# Altium schematic sheet 05_VALVE + heating -1031.SchDoc
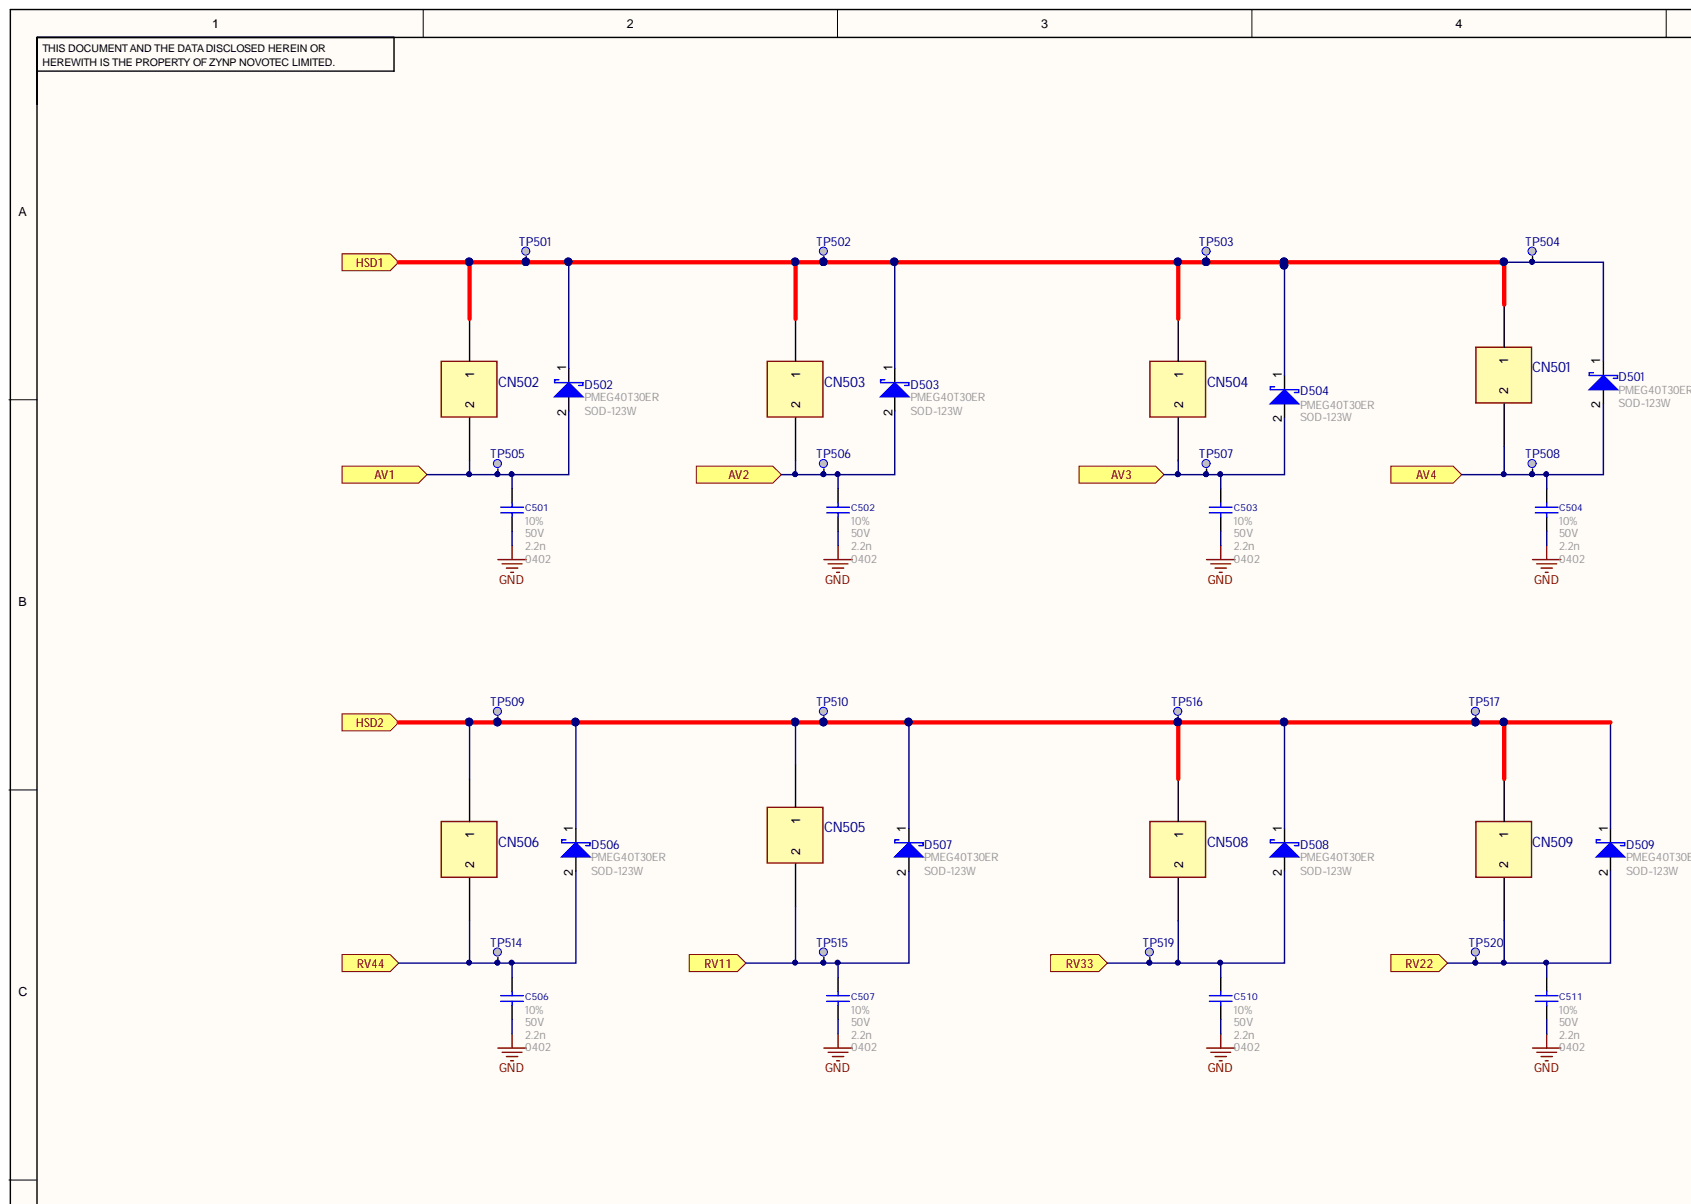
[diagram p0: 05_VALVE + heating -1031.SchDoc, top left]
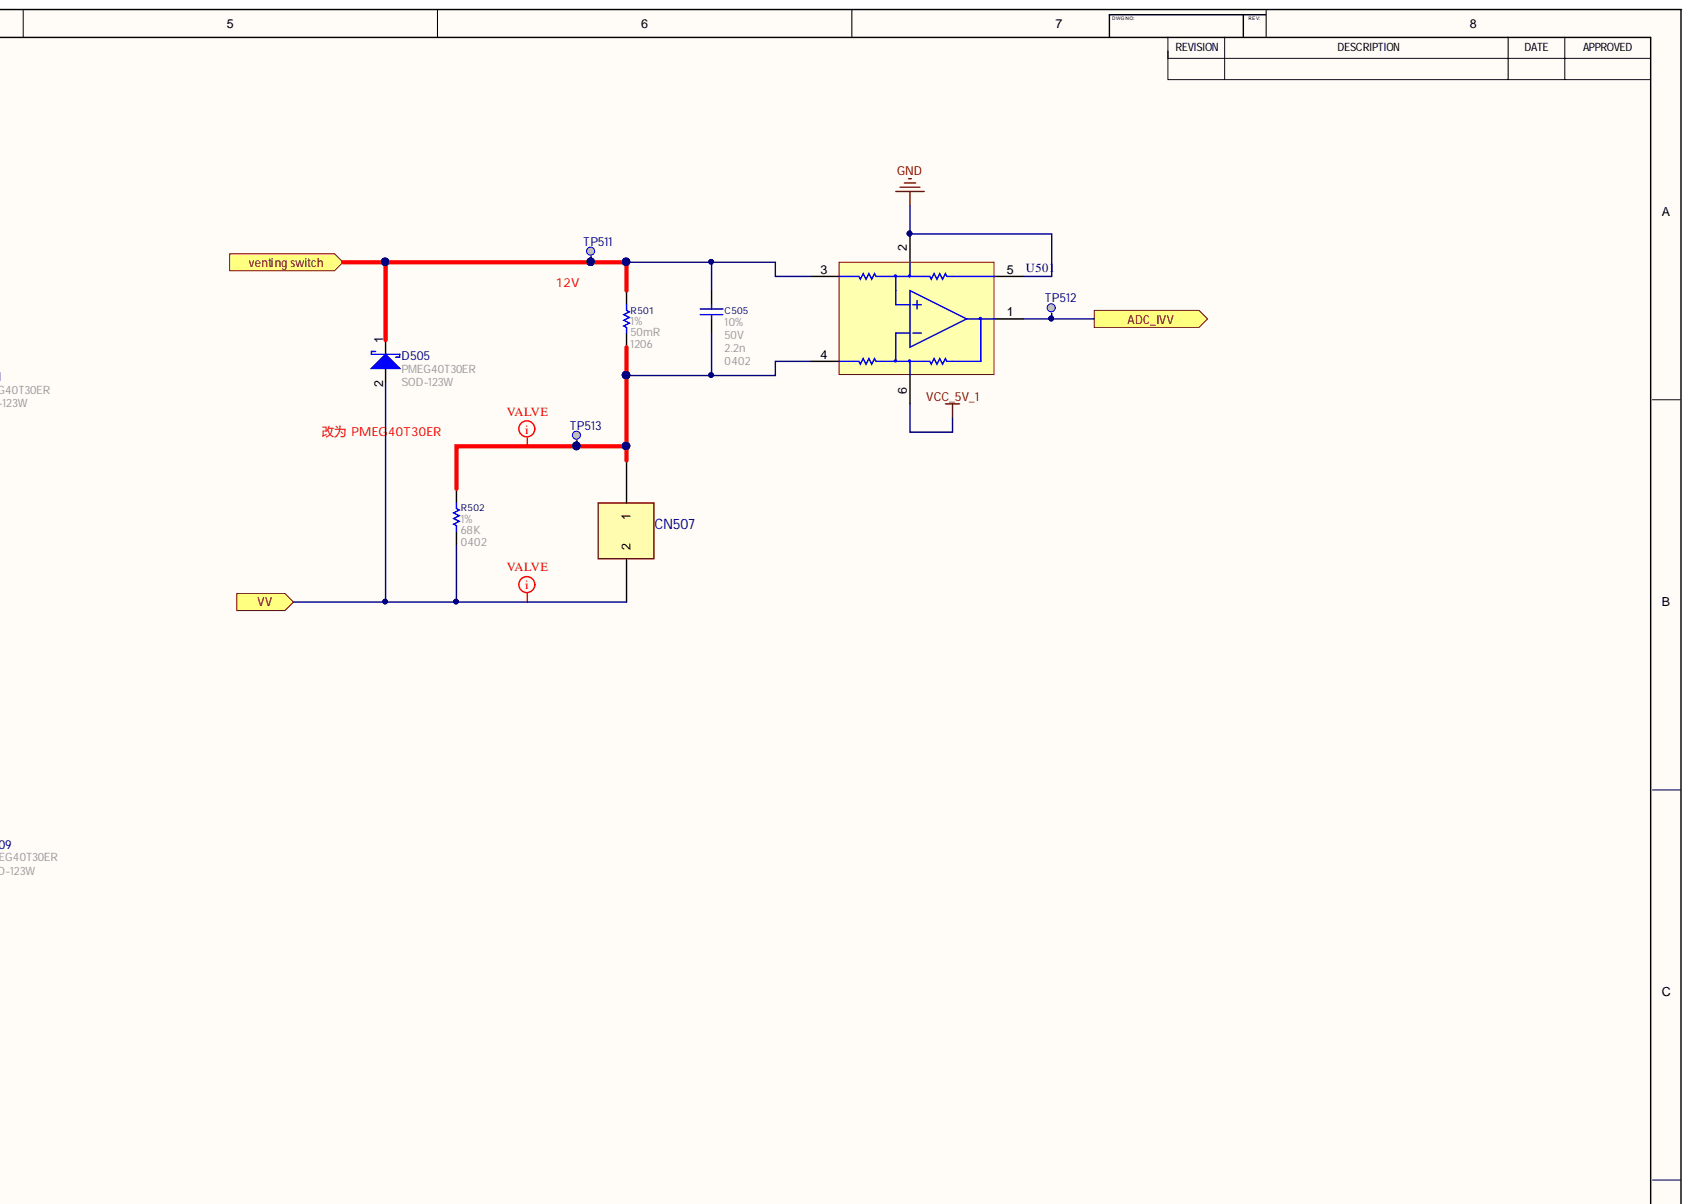
[diagram p1: 05_VALVE + heating -1031.SchDoc, top right]
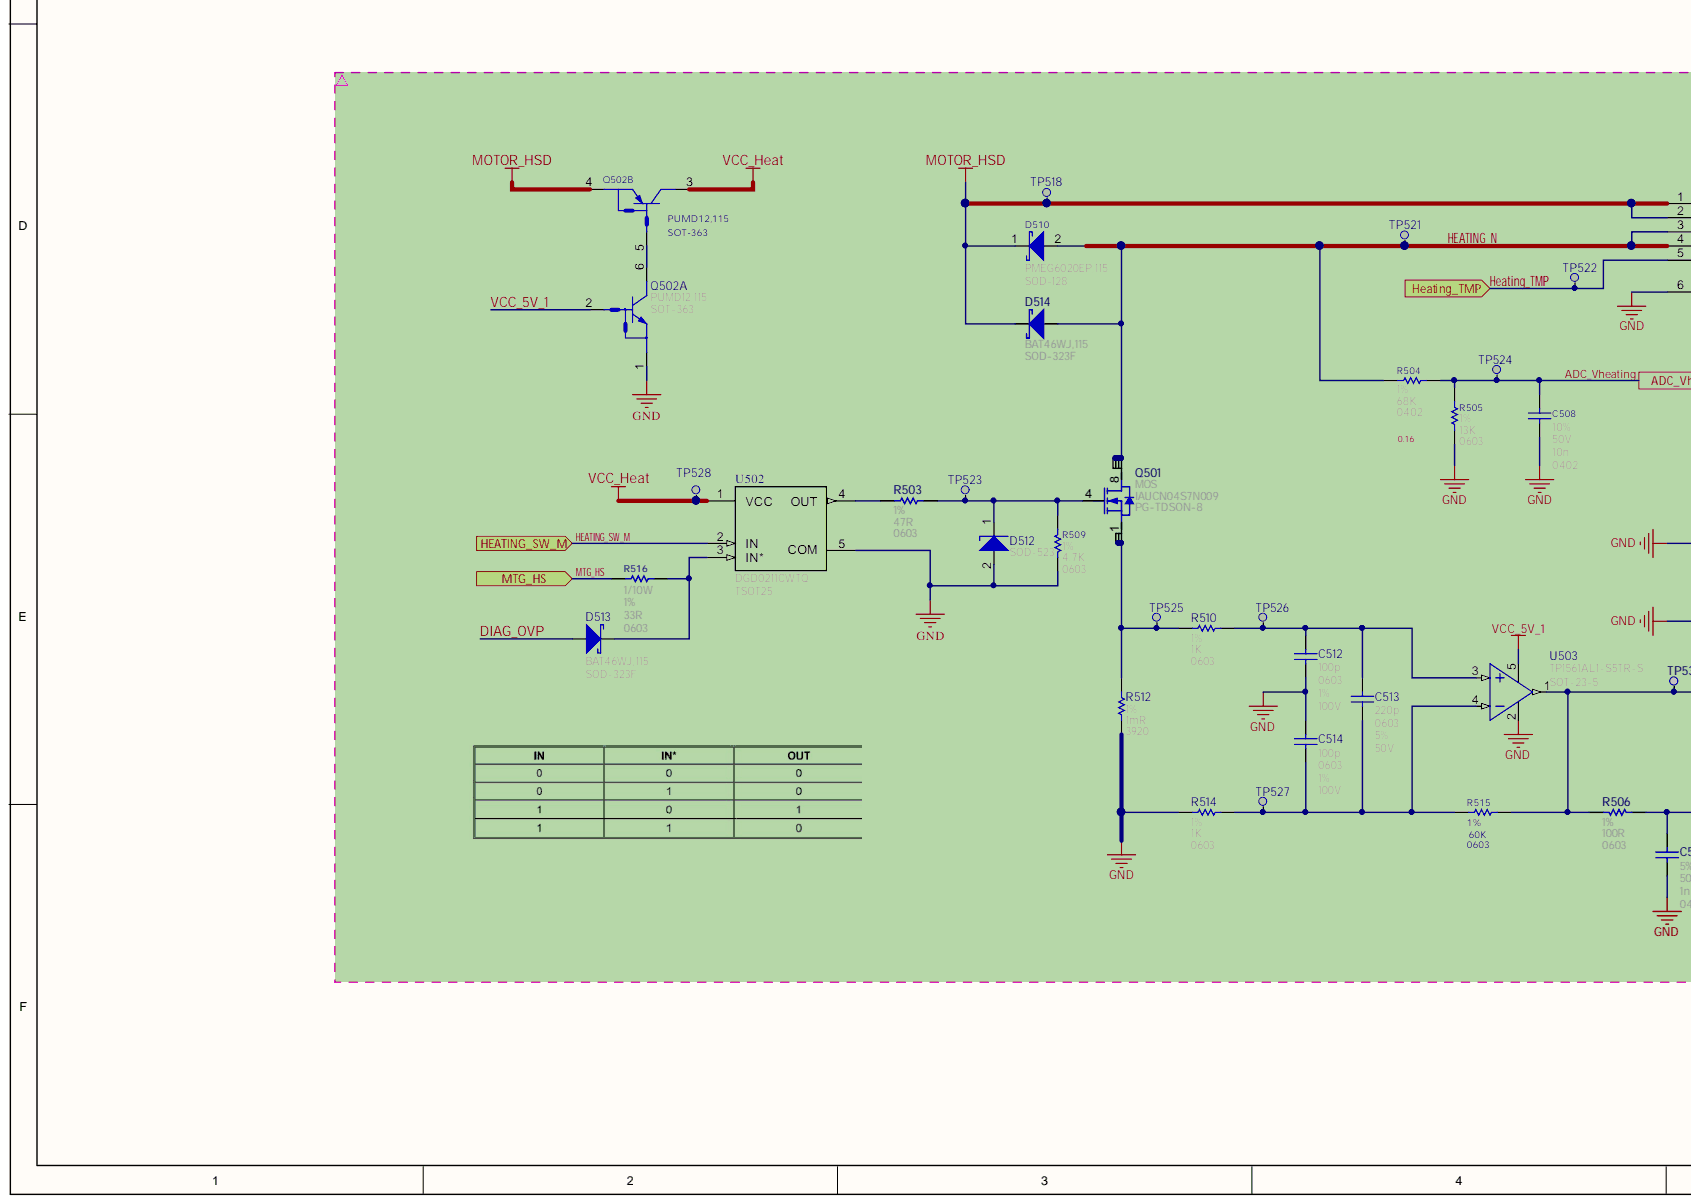
[diagram p2: 05_VALVE + heating -1031.SchDoc, bottom left]
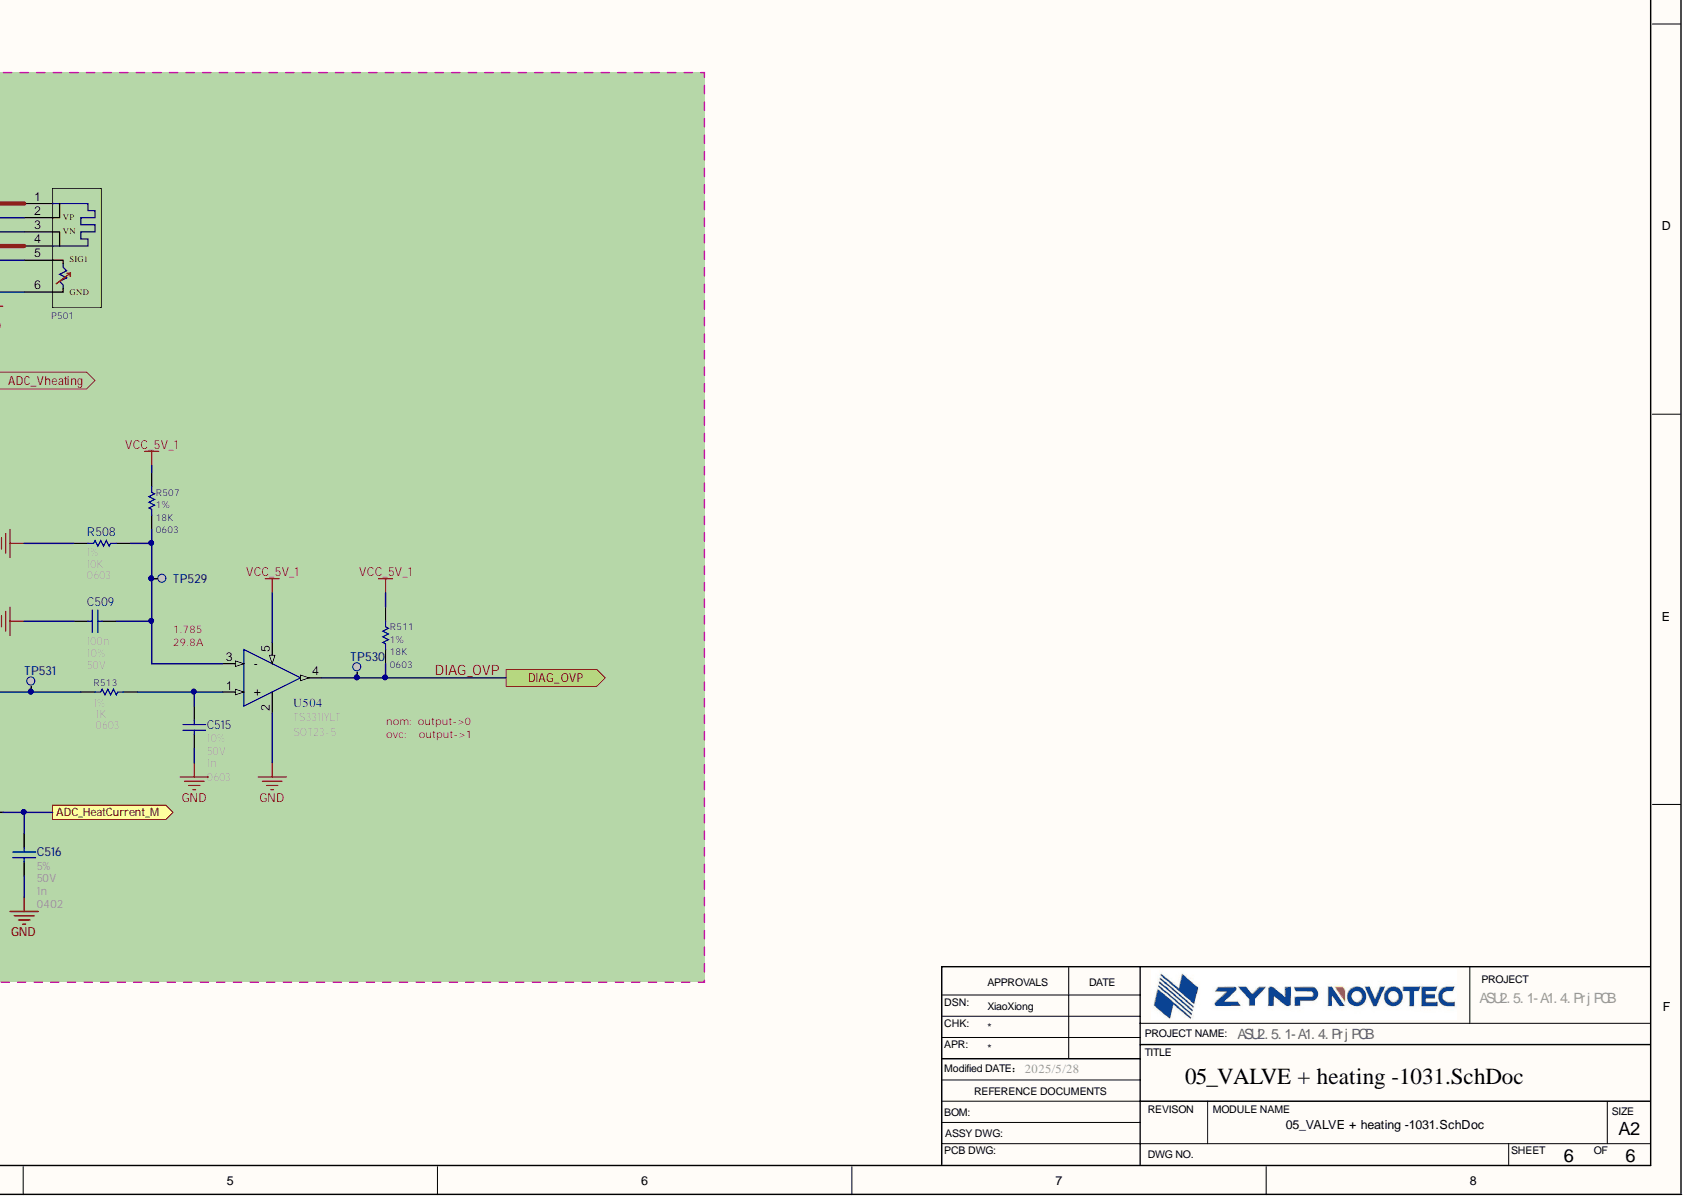
[diagram p3: 05_VALVE + heating -1031.SchDoc, bottom right]

## Parts
C501  value 2.2n  Package: 0402  Tolerance: 10%  Voltage: 50V  [C0402]  (hidden comment: CAP)
C502  value 2.2n  Package: 0402  Tolerance: 10%  Voltage: 50V  [C0402]  (hidden comment: CAP)
C503  value 2.2n  Package: 0402  Tolerance: 10%  Voltage: 50V  [C0402]  (hidden comment: CAP)
C504  value 2.2n  Package: 0402  Tolerance: 10%  Voltage: 50V  [C0402]  (hidden comment: CAP)
C505  value 2.2n  Package: 0402  Tolerance: 10%  Voltage: 50V  [C0402]  (hidden comment: CAP)
C506  value 2.2n  Package: 0402  Tolerance: 10%  Voltage: 50V  [C0402]  (hidden comment: CAP)
C507  value 2.2n  Package: 0402  Tolerance: 10%  Voltage: 50V  [C0402]  (hidden comment: CAP)
C508  value 10n  Package: 0402  Tolerance: 10%  Voltage: 50V  [C0402]  (hidden comment: CAP)
C509  value 100n  Package: 0603  Tolerance: 10%  [C0603]
C510  value 2.2n  Package: 0402  Tolerance: 10%  Voltage: 50V  [C0402]  (hidden comment: CAP)
C511  value 2.2n  Package: 0402  Tolerance: 10%  Voltage: 50V  [C0402]  (hidden comment: CAP)
C512  value 100p  Package: 0603  Tolerance: 1%  [C0603]  (hidden comment: CAP)
C513  value 220p  Package: 0603  Tolerance: 5%  Voltage: 50V  [C0603]  (hidden comment: CAP)
C514  value 100p  Package: 0603  Tolerance: 1%  Voltage: 100V  [C0603]  (hidden comment: CAP)
C515  value 1n  Package: 0603  Tolerance: 10%  Voltage: 50V  [C0603]
C516  value 1n  Package: 0402  Tolerance: 5%  Voltage: 50V  [C0402]  (hidden comment: CAP)
CN501  VALVE-2  [VALVE-HEADER-2 - 0.64PRESSFIT]  (value not drawn)
CN502  VALVE-2  [VALVE-HEADER-2 - 0.64PRESSFIT]  (value not drawn)
CN503  VALVE-2  [VALVE-HEADER-2 - 0.64PRESSFIT]  (value not drawn)
CN504  VALVE-2  [VALVE-HEADER-2 - 0.64PRESSFIT]  (value not drawn)
CN505  VALVE-2  [VALVE-HEADER-2 - 0.64PRESSFIT]  (value not drawn)
CN506  VALVE-2  [VALVE-HEADER-2 - 0.64PRESSFIT]  (value not drawn)
CN507  VALVE-2  [VALVE-HEADER-2 - 0.64PRESSFIT]
CN508  VALVE-2  [VALVE-HEADER-2 - 0.64PRESSFIT]  (value not drawn)
CN509  VALVE-2  [VALVE-HEADER-2 - 0.64PRESSFIT]  (value not drawn)
D501  Part_number: PMEG40T30ER  [SOD-123W]  (hidden comment: Diode)
D502  Part_number: PMEG40T30ER  [SOD-123W]  (hidden comment: Diode)
D503  Part_number: PMEG40T30ER  [SOD-123W]  (hidden comment: Diode)
D504  Part_number: PMEG40T30ER  [SOD-123W]  (hidden comment: Diode)
D505  Part_number: PMEG40T30ER  [SOD-123W]  (hidden comment: Diode)
D506  Part_number: PMEG40T30ER  [SOD-123W]  (hidden comment: Diode)
D507  Part_number: PMEG40T30ER  [SOD-123W]  (hidden comment: Diode)
D508  Part_number: PMEG40T30ER  [SOD-123W]  (hidden comment: Diode)
D509  Part_number: PMEG40T30ER  [SOD-123W]  (hidden comment: Diode)
D510  Part_number: PMEG6020EP,115  [SOD-128]  (hidden comment: Diode)
D512  Diode  [SOD-523]  (value not drawn)
D513  Part_number: BAT46WJ,115  [SOD-323F]  (hidden comment: Diode)
D514  Part_number: BAT46WJ,115  [SOD-323F]  (hidden comment: Diode)
P501  connector  [HEATING-6PIN - NEW]  (value not drawn)
Q501  MOS  Part_number: IAUCN04S7N009  [PG-TDSON-8]
Q502  value PUMD12,115  Part_number: PUMD12,115  [SOT-363]  (hidden comment: Q_PUMD12,115)  (parts A,B)
R501  value 50mR  Package: 1206  Tolerance: 1%  [R1206-L]  (hidden comment: RES)
R502  value 68K  Package: 0402  Tolerance: 1%  [R0402]  (hidden comment: Res)
R503  value 47R  Package: 0603  Tolerance: 1%  [R0603]  (hidden comment: Res)
R504  value 68K  Package: 0402  Tolerance: 1%  [R0402]  (hidden comment: Res)
R505  value 13K  Package: 0603  Tolerance: 1%  [R0603]  (hidden comment: Res)
R506  value 100R  Package: 0603  Tolerance: 1%  [R0603]  (hidden comment: Res)
R507  value 18K  Package: 0603  Tolerance: 1%  [R0603]  (hidden comment: RES)
R508  value 10K  Tolerance: 1%  [R0603]  (hidden comment: Res)
R509  value 4.7K  Package: 0603  Tolerance: 1%  [R0603]  (hidden comment: Res)
R510  value 1K  Package: 0603  Tolerance: 1%  [R0603]  (hidden comment: Res)
R511  value 18K  Package: 0603  Tolerance: 1%  [R0603]  (hidden comment: RES)
R512  value 1mR  Package: 3920  Tolerance: 1%  [R3920]  (hidden comment: RES)
R513  value 1K  Package: 0603  Tolerance: 1%  [R0603]  (hidden comment: RES)
R514  value 1K  Package: 0603  Tolerance: 1%  [R0603]  (hidden comment: Res)
R515  value 60K  Package: 0603  Tolerance: 1%  [R0603]  (hidden comment: RES)
R516  value 33R  Power: 1/10W  Tolerance: 1%  [R0603]  (hidden comment: RES)
TP501  TEST Point  [TP-0.9]  (value not drawn)
TP502  TEST Point  [TP-0.9]  (value not drawn)
TP503  TEST Point  [TP-0.9]  (value not drawn)
TP504  TEST Point  [TP-0.9]  (value not drawn)
TP505  TEST Point  [TP-0.9]  (value not drawn)
TP506  TEST Point  [TP-0.9]  (value not drawn)
TP507  TEST Point  [TP-0.9]  (value not drawn)
TP508  TEST Point  [TP-0.9]  (value not drawn)
TP509  TEST Point  [TP-0.9]  (value not drawn)
TP510  TEST Point  [TP-0.9]  (value not drawn)
TP511  TEST Point  [TP-0.9]  (value not drawn)
TP512  TEST Point  [TP-0.9]  (value not drawn)
TP513  TEST Point  [TP-0.9]  (value not drawn)
TP514  TEST Point  [TP-0.9]  (value not drawn)
TP515  TEST Point  [TP-0.9]  (value not drawn)
TP516  TEST Point  [TP-0.9]  (value not drawn)
TP517  TEST Point  [TP-0.9]  (value not drawn)
TP518  TEST Point  [TP-0.9]  (value not drawn)
TP519  TEST Point  [TP-0.9]  (value not drawn)
TP520  TEST Point  [TP-0.9]  (value not drawn)
TP521  TEST Point  [TP-0.9]  (value not drawn)
TP522  TEST Point  [TP-0.9]  (value not drawn)
TP523  TEST Point  [TP-0.9]  (value not drawn)
TP524  TEST Point  [TP-0.9]  (value not drawn)
TP525  TEST Point  [TP-0.9]  (value not drawn)
TP526  TEST Point  [TP-0.9]  (value not drawn)
TP527  TEST Point  [TP-0.9]  (value not drawn)
TP528  TEST Point  [TP-0.9]  (value not drawn)
TP529  TEST Point  [TP-0.9]  (value not drawn)
TP530  TEST Point  [TP-0.9]  (value not drawn)
TP531  TEST Point  [TP-0.9]  (value not drawn)
U501  U_INA181-Q1  [SOT-23]  (value not drawn)
U502  value DGD0211CWTQ  Part_number: DGD0211CWTQ  [TSOT25]  (hidden comment: U_DGD0211CWTQ-7(TSOT25))
U503  value TP1561AL1-S5TR-S  Part_number: TP1561AL1-S5TR-S  [SOT-23-5]  (hidden comment: Ope Amp)
U504  value TS331IYLT  package: SOT23-5  Part Name: TS331IYLT  [SOT-23-5]  (hidden comment: Comparator)

## Ports
ADC_HeatCurrent_M, ADC_IVV, ADC_Vheating, AV1, AV2, AV3, AV4, DIAG_OVP, HEATING_SW_M, Heating_TMP, HSD1, HSD2, MTG_HS, RV11, RV22, RV33, RV44, venting switch, VV

## Nets
GND: C501.1 C502.1 C503.1 C504.1 C506.1 C507.1 C508.1 C509.2 C510.1 C511.1 C512.1 C514.2 C515.1 C516.1 D512.2 P501.6(GND) Q502.1 R505.1 R508.2 R509.1 R512.2 R514.1 U501.2(GND) U501.5(REF) U502.5(COM) U503.2 U504.2
MOTOR_HSD: D510.1 D514.1 P501.1 P501.2(VP) Q502.4 TP518.1
VCC_Heat: Q502.3 TP528.1 U502.1(VCC)
ADC_HeatCurrent_M: C516.2 R506.1 ; port ADC_HeatCurrent_M
ADC_IVV: TP512.1 U501.1(OUT) ; port ADC_IVV
ADC_Vheating: C508.2 R504.2 R505.2 TP524.1 ; port ADC_Vheating
AV1: C501.2 CN502.2 D502.2 TP505.1 ; port AV1
AV2: C502.2 CN503.2 D503.2 TP506.1 ; port AV2
AV3: C503.2 CN504.2 D504.2 TP507.1 ; port AV3
AV4: C504.2 CN501.2 D501.2 TP508.1 ; port AV4
DIAG_OVP: D513.2 R511.1 TP530.1 U504.4 ; port DIAG_OVP
HEATING_N: D510.2 D514.2 P501.3(VN) P501.4 Q501.5 Q501.6 Q501.7 Q501.8 R504.1 TP521.1
HEATING_SW_M: U502.2(IN) ; port HEATING_SW_M
Heating_TMP: P501.5(SIG1) TP522.1 ; port Heating_TMP
HSD1: CN501.1 CN502.1 CN503.1 CN504.1 D501.1 D502.1 D503.1 D504.1 TP501.1 TP502.1 TP503.1 TP504.1 ; port HSD1
HSD2: CN505.1 CN506.1 CN508.1 CN509.1 D506.1 D507.1 D508.1 D509.1 TP509.1 TP510.1 TP516.1 TP517.1 ; port HSD2
MTG_HS: R516.1 ; port MTG_HS
RV11: C507.2 CN505.2 D507.2 TP515.1 ; port RV11
RV22: C511.2 CN509.2 D509.2 TP520.1 ; port RV22
RV33: C510.2 CN508.2 D508.2 TP519.1 ; port RV33
RV44: C506.2 CN506.2 D506.2 TP514.1 ; port RV44
VCC_5V_1: Q502.2 R507.2 R511.2 U501.6(VS) U503.5 U504.5
venting switch: C505.2 D505.1 R501.2 TP511.1 U501.3(IN+) ; port venting switch
VV: CN507.2 D505.2 R502.1 ; port VV
NetC505_1: C505.1 CN507.1 R501.1 R502.2 TP513.1 U501.4(IN-)
NetC509_1: C509.1 R507.1 R508.1 TP529.1 U504.3(-)
NetC512_2: C512.2 C513.1 R510.2 TP526.1 U503.3
NetC513_2: C513.2 C514.1 R514.2 R515.2 TP527.1 U503.4
NetC515_2: C515.2 R513.2 U504.1(+)
NetD512_1: D512.1 Q501.4 R503.1 R509.2 TP523.1
NetD513_1: D513.1 R516.2 U502.3(IN*)
NetQ501_1: Q501.1 Q501.2 Q501.3 R510.1 R512.1 TP525.1
NetQ502_5: Q502.5 Q502.6
NetR503_2: R503.2 U502.4(OUT)
NetR506_2: R506.2 R513.1 R515.1 TP531.1 U503.1

## Library data of the parts
C501-C507 C510 C511 = C_2.2n_50V_10%_GCM155R71H222KA37D: 112000095; Manufacturer: Murata; Location: Japan; Regulation: GCM155R71H222KA37D、Murata、2.2n、10%、50V、X7R、-55℃~125℃、0402; SAP_number: 10055315; Specification: RoHS AEC-Q200; Temperature_char: X7R; Type: 陶瓷电容; Component Kind: Standard; Library Name: RLC.SchLib; Part_number: GCM155R71H222KA37D; TC_number: 112000095; Temperature: -55℃~125℃
C508 = C_10n_50V_10%_GCM155R71H103KA55D: 112000097; Manufacturer: Murata; Location: Japan; Regulation: GCM155R71H103KA55D、Murata、10n、10%、50V、X7R、-55℃~125℃、0402; SAP_number: 10052234; Specification: RoHS AEC-Q200; Temperature_char: X7R; Type: 陶瓷电容; Component Kind: Standard; Library Name: RLC.SchLib; Part_number: GCM155R71H103KA55D; TC_number: 112000097; Temperature: -55℃~125℃
C509 = C_100n_50V_10%_GCM188R71H104KA57D: 112000101; Manufacturer: Murata; Regulation: GCM188R71H104KA57D、Murata、100n、10%、50V、X7R、-55℃~125℃、0603; SAP_number: 10055330; Specification: RoHS AEC-Q200; Temperature_char: X7R; Type: 陶瓷电容; Voltage: 50V; Component Kind: Standard; Library Name: RLC.SchLib; Part_number: GCM188R71H104KA57D; TC_number: 112000101; Temperature: -55℃~125℃
C512 = C_100p_100V_1%_GCM1885C2A101FA16D: 112000273; Manufacturer: Murata; Location: Japan; Regulation: GCM1885C2A101FA16D、Murata、100p、1%、100V、C0G、-55℃~125℃、0603; SAP_number: 10056567; Specification: RoHS AEC-Q200; Temperature_char: C0G; Type: 陶瓷电容; Voltage: 100V; Component Kind: Standard; Library Name: RLC.SchLib; Part_number: GCM1885C2A101FA16D; TC_number: 112000273; Temperature: -55℃~125℃
C513 = C_220p_50V_5%_GCM1885C1H221JA16D: 112000204; Manufacturer: Murata; Location: Japan; Regulation: GCM1885C1H221JA16D、Murata、220p、5%、50V、NP0、-55℃~125℃、0603; SAP_number: 10052192; Specification: RoHS AEC-Q200; Temperature_char: NP0; Type: 陶瓷电容; Component Kind: Standard; Library Name: RLC.SchLib; Part_number: GCM1885C1H221JA16D; TC_number: 112000204; Temperature: -55℃~125℃
C514 = C_100p_100V_1%_GCM1885C2A101FA16D: 112000273; Manufacturer: Murata; Location: Japan; Regulation: GCM1885C2A101FA16D、Murata、100p、1%、100V、C0G、-55℃~125℃、0603; SAP_number: 10056567; Specification: RoHS AEC-Q200; Temperature_char: C0G; Type: 陶瓷电容; Component Kind: Standard; Library Name: RLC.SchLib; Part_number: GCM1885C2A101FA16D; TC_number: 112000273; Temperature: -55℃~125℃
C515 = C_1n_50V_10%_GCM188R91H102KA37D: 112000189; Manufacturer: Murata; Regulation: GCM188R91H102KA37D、Murata、1n、10%、50V、X8R、-55℃~150℃、0603; SAP_number: 10056371; Specification: RoHS AEC-Q200; Temperature_char: X8R; Type: 陶瓷电容; Component Kind: Standard; Library Name: RLC.SchLib; Part_number: GCM188R91H102KA37D; TC_number: 112000189; Temperature: -55℃~150℃
C516 = C_1n_50V_5%_GCM1555G1H102JA16D: 112000067; Manufacturer: Murata; Location: Japan; Regulation: GCM1555G1H102JA16D、Murata、1n、5%、50V、X8G、-55℃~150℃、0402; SAP_number: 10056779; Specification: RoHS AEC-Q200; Temperature_char: X8G; Type: 陶瓷电容; Component Kind: Standard; Library Name: RLC.SchLib; Part_number: GCM1555G1H102JA16D; TC_number: 112000067; Temperature: -55℃~150℃
D501-D509 = D_40V_PMEG40T30ER: Manufacturer: Nexperia; Forward Current(If): 3A; Peak Forward Current(Ifrm): 4.2A; Regulation: PMEG40T30ER、Nexperia、3A、40V、-65℃~175℃、SOD-123W; Reverse Voltage(Vr): 40V; Specification: RoHS AEC-Q101; type: 肖特基二极管; Component Kind: Standard; Library Name: Diode.SchLib; Package: SOD-123W; Peak Forward Surge Current(Ifsm): 4.2A; Temperature: -65℃-175℃
D510 = D_60V_PMEG6020EP,115: 113000073; Manufacturer: Nexperia; Forward Current(If): 2A; Peak Forward Surge Current(Ifsm): 50A; Regulation: PMEG6020EP,115、Nexperia、2A、60V、-55℃~150℃、SOD-128; Reverse Voltage(Vr): 60V; SAP_number: 10056399; Specification: RoHS AEC-Q101; type: 肖特基二极管; Component Kind: Standard; Library Name: Diode.SchLib; Package: SOD-128; TC_number: 113000073; Temperature: -55℃-150℃
D512 = D_15V_BZX585-C15,115: 113000020; Manufacturer: Nexperia; Forward Current(If): 200mA; Power: 300mW; Regulation: BZX585-C15,115、Nexperia、15V、300mW、-65℃~150℃、SOD-523（SC-79）; Reverse Voltage(Vr): 15V; SAP_number: 10055368; Specification: RoHS AEC-Q101; type: 稳压二极管; Component Kind: Standard; Library Name: Diode.SchLib; Package: SOD-523; Part_number: BZX585-C15,115; TC_number: 113000020; Temperature: -65℃-150℃
D513 D514 = D_100V_BAT46WJ,115: 113000008; Manufacturer: Nexperia; Forward Current(If): 250mA; Peak Forward Surge Current(Ifsm): 2.5A; Regulation: BAT46WJ,115、Nexperia、250mA、100V、-55℃~150℃、SOD-323F; Reverse Voltage(Vr): 100V; SAP_number: 10013859; Specification: RoHS AEC-Q101; type: 肖特基二极管; Component Kind: Standard; Library Name: Diode.SchLib; Package: SOD-323F; TC_number: 113000008; Temperature: -55℃-150℃
P501 = CON_6X1_heating: Pin counter: 6; Component Kind: Standard; Library Name: Header.SchLib; temperature: -40℃～+150℃
Q501 = Q_IAUCN04S7N009: IFX 第七代MOS; Manufacturer: Infineon; Channel_type: N-channel; Id or Ic: 175A; RDS(on): 0.96mΩ@10V; Regulation: IAUCN04S7N009、Infineon、NMOS、175A、±20V、0.96mR、40V、-55℃~175℃、PG-TDSON-8; Specification: RoHS AEC-Q101; Type: NMOSFET; Vds or Vce: 40V; Vgs or Vbe: ±20V; Component Kind: Standard; Library Name: MOSFET.SchLib; Package: PG-TDSON-8; Temperature: -55℃～175℃
Q502 = Q_PUMD12,115: 114000034; Manufacturer: Nexperia; Channel_number: 2; Channel_type: NPN+NPN; Ic: 100mA; Regulation: PUMD12,115、Nexperia、1NPN+1PNP、100mA、50V、-65℃~150℃、SOT-363; Specification: RoHS AEC-Q101; Type: 三极管; Vcbo: 50V; Vebo: 10V; Component Kind: Standard; Library Name: Transistor.SchLib; Package: SOT-363; Temperature: -65℃~150℃; Vceo: 50V
R501 = R_50mR_1W_1%_ HRS-MA120610FR050FA: HRS-MA120610FR050FA、HORUS、50mR、1%、1W、-55℃~155℃、1206; Manufacturer: HORUS; Location: China; Power: 1W; Specification: RoHS AEC-Q200; Component Kind: Standard; Library Name: RLC.SchLib; part_number: HRS-MA120610FR050FA; Regulation: HRS-MA120610FR050FA、HORUS、50mR、1%、1W、-55℃~155℃、1206; Temperature: -55～155℃; Type: 采样电阻
R502 R504 = R_68K_1/16W_1%_AC0402FR-0768KL: AC0402FR-0768KL、YAGEO、68K、1%、0402; Manufacturer: YAGEO; Location: taiwan; Power: 1/16W; Specification: RoHS AEC-Q200; Component Kind: Standard; Library Name: RLC.SchLib; Part_number: AC0402FR-0768KL; Regulation: AC0402FR-0768KL、YAGEO、68K、1%、0402; Temperature: -55℃～155℃; Type: 厚膜电阻
R503 = R_47R_1/10W_1%_AC0603FR-0747RL: AC0603FR-0747RL、YAGEO、47R、1%、0603; Manufacturer: YAGEO; Location: taiwan; Power: 1/10W; Specification: RoHS AEC-Q200; Component Kind: Standard; Library Name: RLC.SchLib; Part_number: AC0603FR-0747RL; Regulation: AC0603FR-0747RL、YAGEO、47R、1%、0603; Temperature: -55℃～155℃; Type: 厚膜电阻
R505 = R_13K_1/10W_1%_AC0603FR-0713KL: Manufacturer: YAGEO; Location: taiwan; Power: 1/10W; Regulation: AC0603FR-0713KL、YAGEO、13K、1%、0603; Specification: RoHS AEC-Q200; Component Kind: Standard; Library Name: RLC.SchLib; Part_number: AC0603FR-0713KL; Temperature: -55℃～155℃; Type: 厚膜电阻
R506 = R_100R_1/10W_1%_AC0603FR-07100RL: Manufacturer: YAGEO; Location: taiwan; Power: 1/10W; Regulation: AC0603FR-07100RL、YAGEO、100R、1%、0603; Specification: RoHS AEC-Q200; Component Kind: Standard; Library Name: RLC.SchLib; Part_number: AC0603FR-07100RL; Temperature: -55℃～155℃; Type: 厚膜电阻
R507 R511 = R_18K_1/10W_1%_AC0603FR-0718KL_0603: AC0603FR-0718KL、YAGEO、18K、1%、0603; Manufacturer: YAGEO; Location: taiwan; Power: 1/10W; Specification: RoHS AEC-Q200; Component Kind: Standard; Library Name: RLC.SchLib; Part_number: AC0603FR-0718KL; Regulation: AC0603FR-0718KL、YAGEO、18K、1%、0603; Temperature: -55℃～155℃; Type: 厚膜电阻
R508 = R_10K_1/10W_1%_AC0603FR-0710KL: Manufacturer: YAGEO; Location: taiwan; Power: 1/10W; Regulation: AC0603FR-0710KL、YAGEO、10K、1%、0603; Specification: RoHS AEC-Q200; Component Kind: Standard; Library Name: RLC.SchLib; Package: 0603; Part_number: AC0603FR-0710KL; Temperature: -55℃～155℃; Type: 厚膜电阻
R509 = R_4.7K_1/10W_1%_AC0603FR-074K7L: AC0603FR-074K7L、YAGEO、4.7K、1%、0603; Manufacturer: YAGEO; Location: taiwan; Power: 1/10W; Specification: RoHS AEC-Q200; Component Kind: Standard; Library Name: RLC.SchLib; Part_number: AC0603FR-074K7L; Regulation: AC0603FR-074K7L、YAGEO、4.7K、1%、0603; Temperature: -55℃～155℃; Type: 厚膜电阻
R510 R514 = R_1K_1/10W_1%_AC0603FR-071KL: Manufacturer: YAGEO; Location: taiwan; Power: 1/10W; Regulation: AC0603FR-071KL、YAGEO、1K、1%、0603; Specification: RoHS AEC-Q200; Component Kind: Standard; Library Name: RLC.SchLib; Part_number: AC0603FR-071KL; Temperature: -55℃～155℃; Type: 厚膜电阻
R512 = R_1mR_8W_1%_HRS-SRN392080FR001MZ_3920: HRS-SRN392080FR001MZ、HORUS、1mR、1%、8W、-55℃~170℃、3920、shunt; Manufacturer: HORUS; Location: China; Power: 8W; Specification: RoHS AEC-Q200; Component Kind: Standard; Library Name: RLC.SchLib; part_number: HRS-SRN392080FR001MZ; Regulation: HRS-SRN392080FR001MZ、HORUS、1mR、1%、8W、-55℃~170℃、3920、shunt; Temperature: -55～170℃; Type: 采样电阻
R513 = R_1K_1/10W_1%_AC0603FR-071KL_0603: AC0603FR-071KL、YAGEO、1K、1%、0603; Manufacturer: YAGEO; a: 111000145; Location: taiwan; Power: 1/10W; Specification: RoHS AEC-Q200; Component Kind: Standard; Library Name: RLC.SchLib; Part_number: AC0603FR-071KL; Regulation: AC0603FR-071KL、YAGEO、1K、1%、0603; Temperature: -55℃～155℃; Type: 厚膜电阻
R515 = R_60K_1/16W_1%_AC0603FR-0760KL_0603: AC0603FR-0760KL、YAGEO、60K、1%、0603; Manufacturer: YAGEO; Location: taiwan; Power: 1/16W; Specification: RoHS AEC-Q200; a: AC0603FR-0760KL、YAGEO、60K、1%、0603; Component Kind: Standard; Library Name: RLC.SchLib; Part_number: AC0603FR-0760KL; Regulation: AC0603FR-0760KL、YAGEO、60K、1%、0603; Temperature: -55℃～155℃; Type: 厚膜电阻
R516 = R_33R_1/10W_1%_ AC0603FR-0733RL_0603: AC0603FR-0733RL、YAGEO、33R、1%、1/10W、-55℃~155℃、0603; Manufacturer: YAGEO; a: 111000363; Location: taiwan; SAP_number: 10099977; Specification: RoHS AEC-Q200; TC_number: 111000385; Value: 33R; Component Kind: Standard; Library Name: RLC.SchLib; Package: 0603; Part_number: AC0603FR-0733RL; Regulation: AC0603FR-0733RL、YAGEO、33R、1%、1/10W、-55℃~155℃、0603; Temperature: -55℃～155℃; Type: 厚膜电阻
TP501-TP531 = TEST_PIN_0.9: TP; Component Kind: Standard; Library Name: Header.SchLib; Package: TP-0.9; Type: test pin; Value: TEST
U501 = U_INA181-Q1: 运放IC; Manufacturer: TI; Part_number: INA181A1QDBVRQ1; abrand location: Germany; AECQ: RoHS AEC-Q100; Component Kind: Standard; Package: SOT-23; Type: 运放IC; Value: INA181-Q1; Library Name: IC - 复制.SchLib
U502 = U_DGD0211CWTQ-7(TSOT25): Manufacturer: DIODES; Location: US; Pin counter: 5; Regulation: DGD0211CWTQ、DIODES、栅极驱动LSD、-40℃~125℃、TSOT25; Component Kind: Standard; Library Name: IC.SchLib; Package: TSOT25; Temperature: -40℃~125℃; Type: 栅极驱动IC (LSD)
U503 = U_TP1561AL1-S5TR-S(SOT-23-5): 117000189; Manufacturer: 3Peak; Pin counter: 5; Regulation: TP1561AL1-S5TR-S、3Peak、运算放大器、-40℃～125℃、SOT23-5; SAP_number: 10060257; Component Kind: Standard; Library Name: IC.SchLib; Package: SOT-23-5; TC_number: 117000189; Temperature: -40℃~125℃; Type: 运算放大器
U504 = U_TS331IYLT(SOT23-5): TS331IYLT、ST、R2R比较器、-40℃~125℃、SOT23-5; Manufacturer: ST; Component Kind: Standard; Library Name: IC.SchLib; part number: TS331IYLT; regulation: TS331IYLT、ST、R2R比较器、-40℃~125℃、SOT23-5
CN501-CN509 = VALVE-2: Component Kind: Standard; Library Name: DBS-C1_Library.SchLib
